# Altium schematic sheet Sheet1.SchDoc(Sheet1)
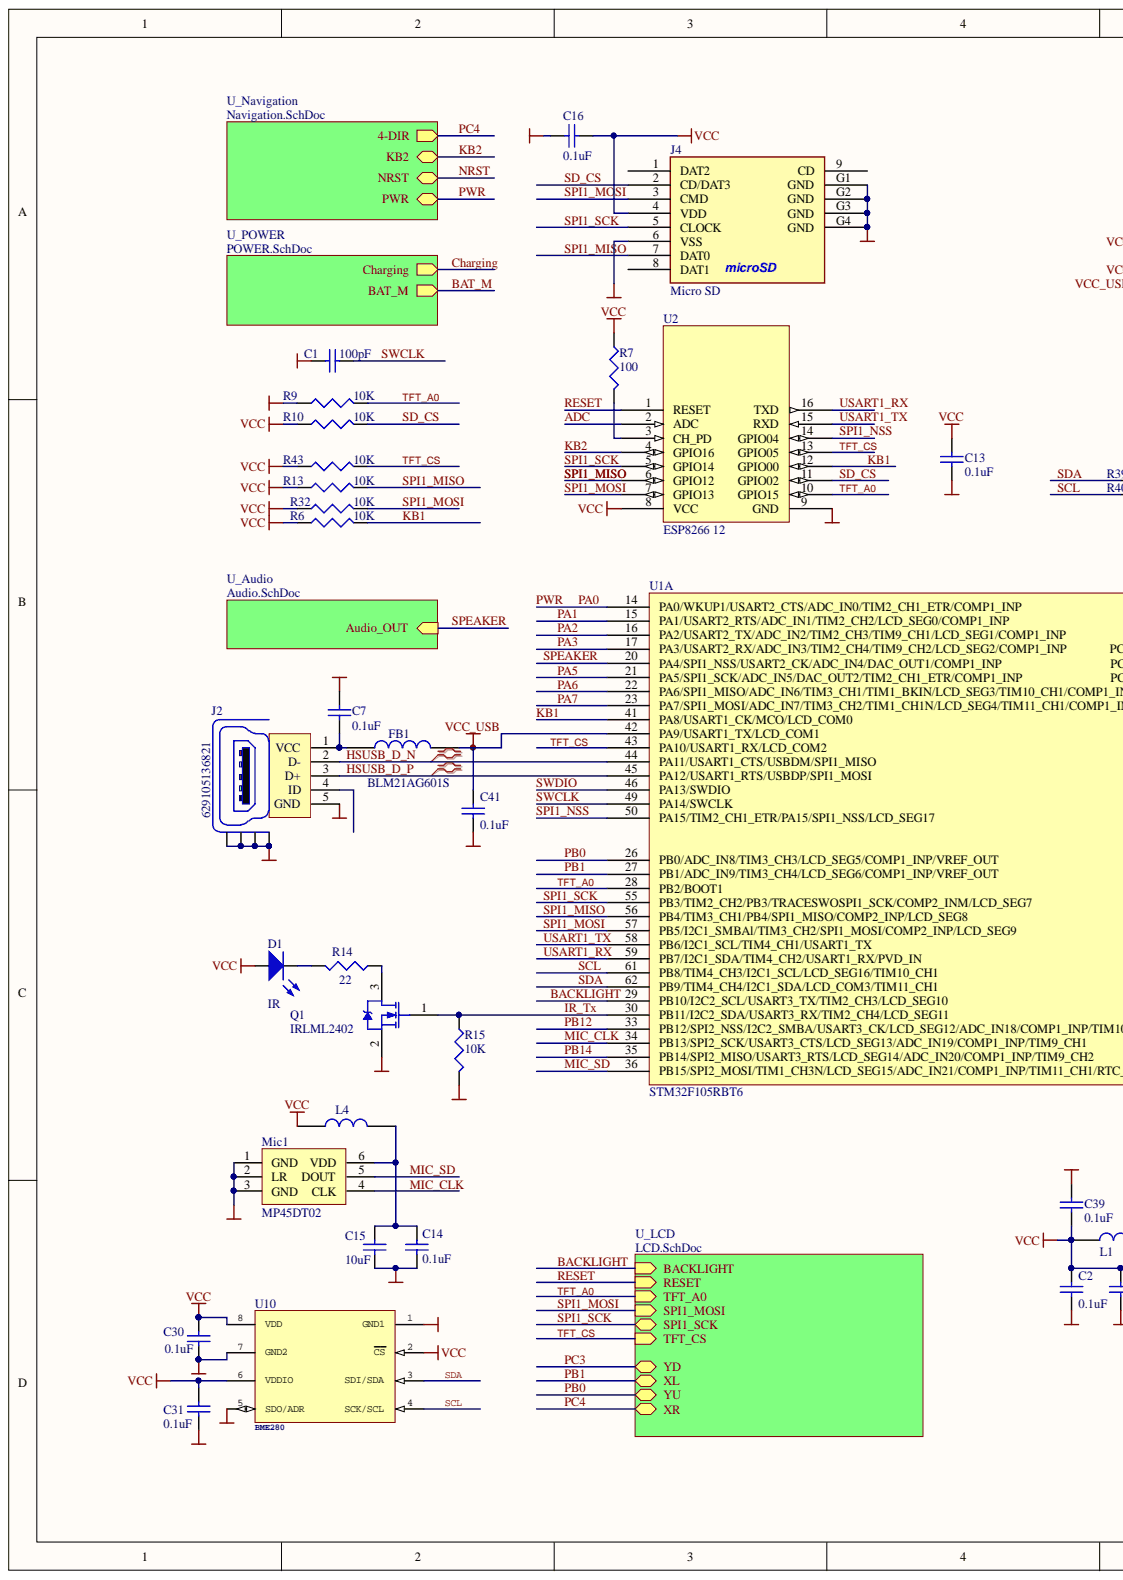
[diagram p0: Sheet1.SchDoc, left]
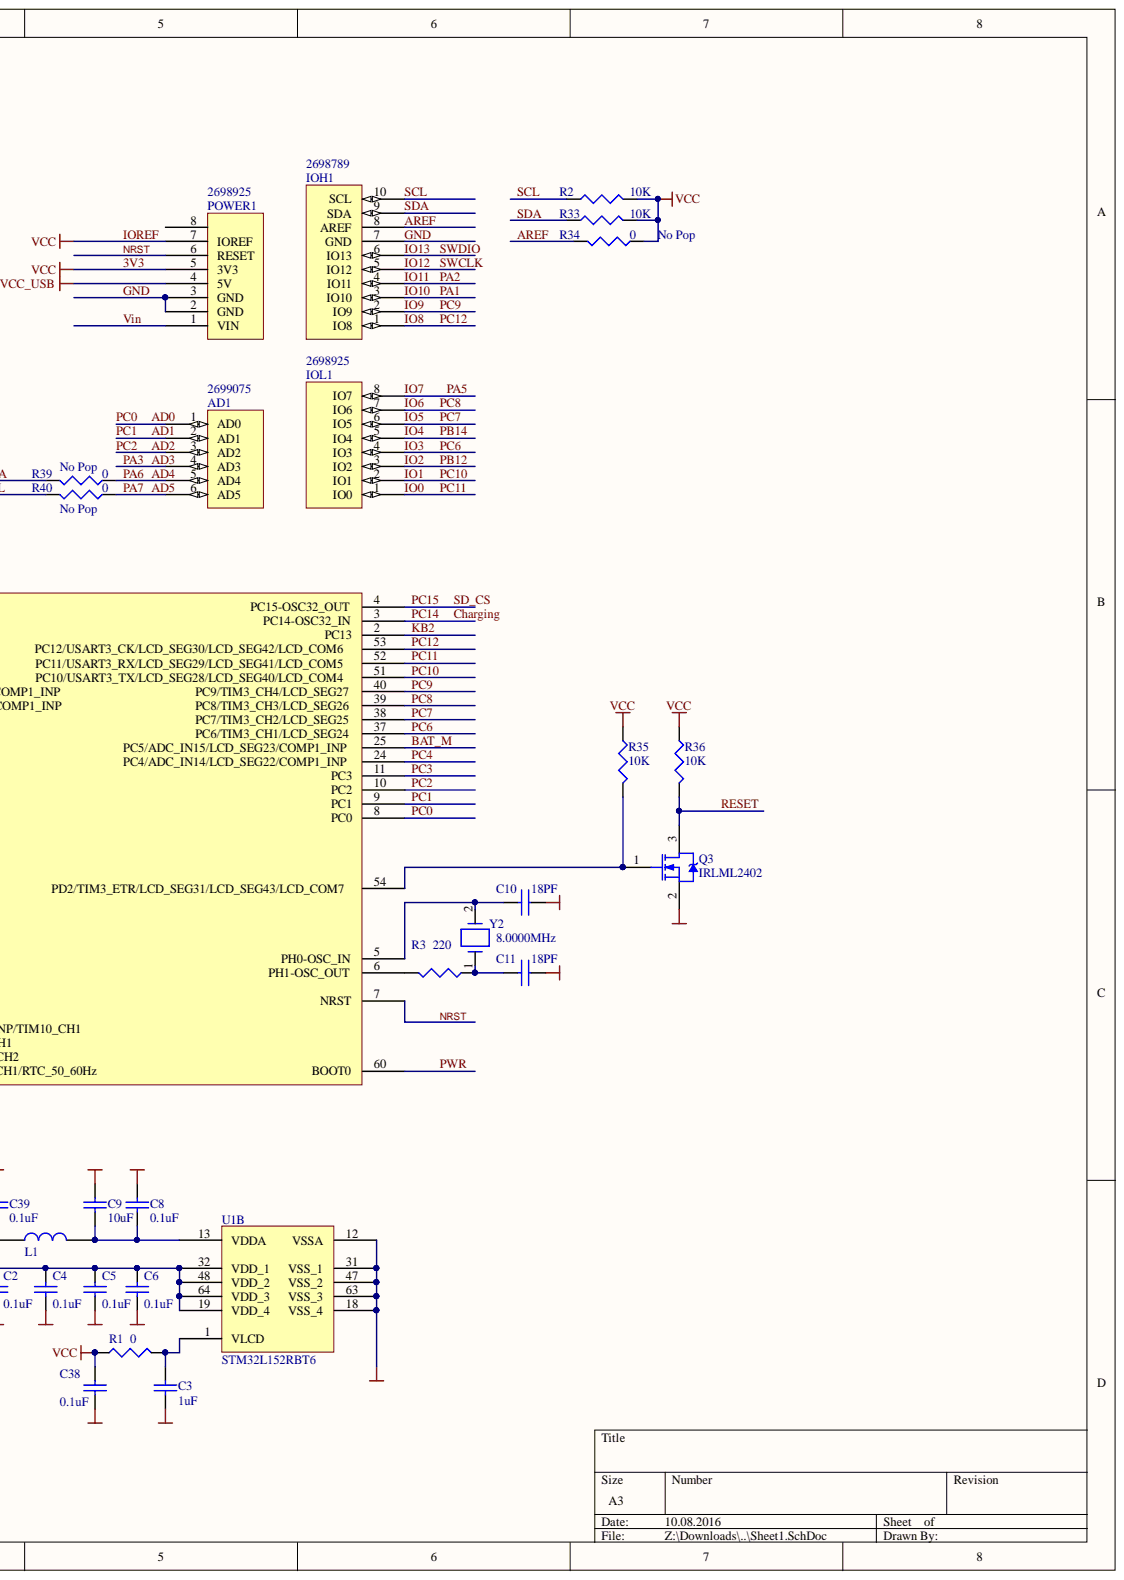
[diagram p1: Sheet1.SchDoc, right]

## Parts
AD1  AD  Supplier Part Number 4: 2699075  [Header 6x1 8.5mm]
C1  100pF  [CAPC1608N]
C2  0.1uF  [CAPC1005N]
C3  value 1uF  [CAPC1608N]  (hidden comment: 1uF 25V 20% 0603)
C4  0.1uF  [CAPC1005N]
C5  0.1uF  [CAPC1005N]
C6  0.1uF  [CAPC1005N]
C7  0.1uF  [CAPC1005N]
C8  0.1uF  [CAPC1005N]
C9  value 10uF  [CAPC1608N]  (hidden comment: CAP, MLCC, X5R, 10UF, 6.3V, 0603)
C10  18PF  [CAPC1608N]
C11  18PF  [CAPC1608N]
C13  0.1uF  [CAPC1005N]
C14  0.1uF  [CAPC1005N]
C15  value 10uF  [CAPC1608N]  (hidden comment: CAP, MLCC, X5R, 10UF, 6.3V, 0603)
C16  0.1uF  [CAPC1005N]
C30  0.1uF  [CAPC1005N]
C31  0.1uF  [CAPC1005N]
C38  0.1uF  [CAPC1005N]
C39  0.1uF  [CAPC1005N]
C41  0.1uF  [CAPC1005N]
D1  IR  [LED_5mm]
FB1  BLM21AG601S  [INDC2012N]
IOH1  IOH  Supplier Part Number 3: 2698789  [Header 10x1 8.5mm]
IOL1  IOL  Supplier Part Number 4: 2698925  [Header 8x1 8.5mm]
J2  629105136821  [629105136821]
J4  Micro SD  [TFP-09-2-12B]
L1  470OHM  [INDC1608N]  (value not drawn)
L4  470OHM  [INDC1608N]  (value not drawn)
Mic1  MP45DT02  [HLGA-6]
POWER1  Supplier Part Number 4: 2698925  [Header 8x1 8.5mm]  (hidden comment: POWER_UNO)
Q1  IRLML2402  [SOT-23]
Q3  IRLML2402  [SOT-23]
R1  0  [RESC1005N]
R2  10K  [RESC1005N]
R3  220  [RESC1005N]
R6  10K  [RESC1005N]
R7  value 100  [RESC1608N]  (hidden comment: RESISTOR;0603;1/10W;110 Ohm 5%)
R9  value 10K  [RESC1005N]  (hidden comment: RESISTOR 10K 1/10W 5% 0402)
R10  value 10K  [RESC1005N]  (hidden comment: RESISTOR 10K 1/10W 5% 0402)
R13  value 10K  [RESC1005N]  (hidden comment: RESISTOR 10K 1/10W 5% 0402)
R14  value 22  [RESC3216N]  (hidden comment: RES 22  OHM 5% 0.250W 1206)
R15  10K  [RESC1005N]
R32  10K  [RESC1005N]
R33  10K  [RESC1005N]
R34  0  ASSY_OPT: No Pop  [RESC1005N]
R35  10K  [RESC1005N]
R36  10K  [RESC1005N]
R39  0  ASSY_OPT: No Pop  [RESC1005N]
R40  0  ASSY_OPT: No Pop  [RESC1005N]
R43  value 10K  [RESC1005N]  (hidden comment: RESISTOR 10K 1/10W 5% 0402)
U1  STM32F105RBT6  [STM-LQFP64_N]  (parts A,B)
U2  ESP8266 12  [ESP-12-GREEN]
U10  BME280  [BME280_LGA]
Y2  value 8.0000MHz  [QUARTZ_5x3.2]  (hidden comment: Crystal 8.0000MHz 30ppm 18pF)

## Nets
VCC_USB: C41.1 FB1.2 POWER1.4(5V) U1.42(PA9/USART1_TX/LCD_COM1)
3V3: C2.1 C4.1 C5.1 C6.1 C13.1 C16.1 C30.1 C31.1 C38.1 C39.1 D1.1 J4.4(VDD) L1.2 L4.1 POWER1.5(3V3) POWER1.7(IOREF) R1.2 R2.1 R6.2 R7.1 R10.1 R13.1 R32.2 R33.1 R34.1 R35.2 R36.2 R43.1 U1.19(VDD_4) U1.32(VDD_1) U1.48(VDD_2) U1.64(VDD_3) U2.8(VCC) U10.2(CS) U10.6(VDDIO) U10.8(VDD)
AD0: AD1.1(AD0) U1.8(PC0)
AD1: AD1.2 U1.9(PC1)
AD2: AD1.3(AD2) U1.10(PC2)
AD3: AD1.4(AD3) U1.17(PA3/USART2_RX/ADC_IN3/TIM2_CH4/TIM9_CH2/LCD_SEG2/COMP1_INP)
AD4: AD1.5(AD4) R39.1 U1.22(PA6/SPI1_MISO/ADC_IN6/TIM3_CH1/TIM1_BKIN/LCD_SEG3/TIM10_CH1/COMP1_INP)
AD5: AD1.6(AD5) R40.1 U1.23(PA7/SPI1_MOSI/ADC_IN7/TIM3_CH2/TIM1_CH1N/LCD_SEG4/TIM11_CH1/COMP1_INP)
ADC: U2.2(ADC)
AREF: IOH1.8(AREF) R34.2
BACKLIGHT: U1.29(PB10/I2C2_SCL/USART3_TX/TIM2_CH3/LCD_SEG10)
BAT_M: U1.25(PC5/ADC_IN15/LCD_SEG23/COMP1_INP)
CHARGING: U1.3(PC14-OSC32_IN)
GND: C1.1 C2.2 C3.2 C4.2 C5.2 C6.2 C7.2 C8.2 C9.1 C10.1 C11.1 C13.2 C14.1 C15.1 C16.2 C30.2 C31.2 C38.2 C39.2 C41.2 IOH1.7(GND) J2.5(GND) J2.Z1 J2.Z2 J2.Z3 J2.Z4 J4.6(VSS) J4.G1(GND) J4.G2(GND) J4.G3(GND) J4.G4(GND) Mic1.1(GND) Mic1.2(LR) Mic1.3(GND) POWER1.2(GND) POWER1.3(GND) Q1.2 Q3.2 R9.1 R15.1 U1.12(VSSA) U1.18(VSS_4) U1.31(VSS_1) U1.47(VSS_2) U1.63(VSS_3) U2.9(GND) U10.1(GND1) U10.5(SDO/ADR) U10.7(GND2)
HSUSB_D_N: J2.2(D-) U1.44(PA11/USART1_CTS/USBDM/SPI1_MISO)
HSUSB_D_P: J2.3(D+) U1.45(PA12/USART1_RTS/USBDP/SPI1_MOSI)
IO0: IOL1.1(IO0) U1.52(PC11/USART3_RX/LCD_SEG29/LCD_SEG41/LCD_COM5)
IO1: IOL1.2(IO1) U1.51(PC10/USART3_TX/LCD_SEG28/LCD_SEG40/LCD_COM4)
IO2: IOL1.3(IO2) U1.33(PB12/SPI2_NSS/I2C2_SMBA/USART3_CK/LCD_SEG12/ADC_IN18/COMP1_INP/TIM10_CH1)
IO3: IOL1.4(IO3) U1.37(PC6/TIM3_CH1/LCD_SEG24)
IO4: IOL1.5(IO4) U1.35(PB14/SPI2_MISO/USART3_RTS/LCD_SEG14/ADC_IN20/COMP1_INP/TIM9_CH2)
IO5: IOL1.6(IO5) U1.38(PC7/TIM3_CH2/LCD_SEG25)
IO6: IOL1.7(IO6) U1.39(PC8/TIM3_CH3/LCD_SEG26)
IO7: IOL1.8(IO7) U1.21(PA5/SPI1_SCK/ADC_IN5/DAC_OUT2/TIM2_CH1_ETR/COMP1_INP)
IO8: IOH1.1(IO8) U1.53(PC12/USART3_CK/LCD_SEG30/LCD_SEG42/LCD_COM6)
IO9: IOH1.2(IO9) U1.40(PC9/TIM3_CH4/LCD_SEG27)
IO10: IOH1.3(IO10) U1.15(PA1/USART2_RTS/ADC_IN1/TIM2_CH2/LCD_SEG0/COMP1_INP)
IO11: IOH1.4(IO11) U1.16(PA2/USART2_TX/ADC_IN2/TIM2_CH3/TIM9_CH1/LCD_SEG1/COMP1_INP)
IO12: C1.2 IOH1.5(IO12) U1.49(PA14/SWCLK)
IO13: IOH1.6(IO13) U1.46(PA13/SWDIO)
IR_TX: Q1.1 R15.2 U1.30(PB11/I2C2_SDA/USART3_RX/TIM2_CH4/LCD_SEG11)
KB1: R6.1 U1.41(PA8/USART1_CK/MCO/LCD_COM0) U2.12(GPIO00)
KB2: U1.2(PC13) U2.4(GPIO16)
MIC_CLK: Mic1.4(CLK) U1.34(PB13/SPI2_SCK/USART3_CTS/LCD_SEG13/ADC_IN19/COMP1_INP/TIM9_CH1)
MIC_SD: Mic1.5(DOUT) U1.36(PB15/SPI2_MOSI/TIM1_CH3N/LCD_SEG15/ADC_IN21/COMP1_INP/TIM11_CH1/RTC_50_60Hz)
NRST: POWER1.6(RESET) U1.7(NRST)
PA0: U1.14(PA0/WKUP1/USART2_CTS/ADC_IN0/TIM2_CH1_ETR/COMP1_INP) U1.60(BOOT0)
PB0: U1.26(PB0/ADC_IN8/TIM3_CH3/LCD_SEG5/COMP1_INP/VREF_OUT)
PB1: U1.27(PB1/ADC_IN9/TIM3_CH4/LCD_SEG6/COMP1_INP/VREF_OUT)
PC3: U1.11(PC3)
PC4: U1.24(PC4/ADC_IN14/LCD_SEG22/COMP1_INP)
PC15: J4.2(CD/DAT3) R10.2 U1.4(PC15-OSC32_OUT) U2.11(GPIO02)
RESET: Q3.3 R36.1 U2.1(RESET)
SCL: IOH1.10(SCL) R2.2 R40.2 U1.61(PB8/TIM4_CH3/I2C1_SCL/LCD_SEG16/TIM10_CH1) U10.4(SCK/SCL)
SDA: IOH1.9(SDA) R33.2 R39.2 U1.62(PB9/TIM4_CH4/I2C1_SDA/LCD_COM3/TIM11_CH1) U10.3(SDI/SDA)
SPEAKER: U1.20(PA4/SPI1_NSS/USART2_CK/ADC_IN4/DAC_OUT1/COMP1_INP)
SPI1_MISO: J4.7(DAT0) R13.2 U1.56(PB4/TIM3_CH1/PB4/SPI1_MISO/COMP2_INP/LCD_SEG8) U2.6(GPIO12)
SPI1_MOSI: J4.3(CMD) R32.1 U1.57(PB5/I2C1_SMBAl/TIM3_CH2/SPI1_MOSI/COMP2_INP/LCD_SEG9) U2.7(GPIO13)
SPI1_NSS: U1.50(PA15/TIM2_CH1_ETR/PA15/SPI1_NSS/LCD_SEG17) U2.14(GPIO04)
SPI1_SCK: J4.5(CLOCK) U1.55(PB3/TIM2_CH2/PB3/TRACESWOSPI1_SCK/COMP2_INM/LCD_SEG7) U2.5(GPIO14)
TFT_A0: R9.2 U1.28(PB2/BOOT1) U2.10(GPIO15)
TFT_CS: R43.2 U1.43(PA10/USART1_RX/LCD_COM2) U2.13(GPIO05)
USART1_RX: U1.59(PB7/I2C1_SDA/TIM4_CH2/USART1_RX/PVD_IN) U2.16(TXD)
USART1_TX: U1.58(PB6/I2C1_SCL/TIM4_CH1/USART1_TX) U2.15(RXD)
VIN: POWER1.1(VIN)
NetC3_1: C3.1 R1.1 U1.1(VLCD)
NetC7_1: C7.1 FB1.1 J2.1(VCC)
NetC8_1: C8.1 C9.2 L1.1 U1.13(VDDA)
NetC10_2: C10.2 U1.5(PH0-OSC_IN) Y2.2
NetC11_2: C11.2 R3.2 Y2.1
NetC14_2: C14.2 C15.2 L4.2 Mic1.6(VDD)
NetD1_2: D1.2 R14.2
NetJ2_4: J2.4(ID)
NetJ4_1: J4.1(DAT2)
NetJ4_8: J4.8(DAT1)
NetJ4_9: J4.9(CD)
NetPOWER1_8: POWER1.8
NetQ1_3: Q1.3 R14.1
NetQ3_1: Q3.1 R35.1 U1.54(PD2/TIM3_ETR/LCD_SEG31/LCD_SEG43/LCD_COM7)
NetR3_1: R3.1 U1.6(PH1-OSC_OUT)
NetR7_2: R7.2 U2.3(CH_PD)

## Library data of the parts
C1 = Cap: CAP, MLCC, X7R, 100PF, 100V, 0603; Manufacturer: AVX; Part Number: 06031C101KAT2A; Component Kind: Standard; Distributor: Farnell: 2332570; Library Name: Miscellaneous Devices.IntLib; PackageReference: 0603; Price: 0.03; Replacement: CAP, MLCC, X7R, 100PF, 100V, 0603; Signal Integrity: Cap; Simulation: CAP; Value: 100pF
C2 C4-C8 C13 C14 C16 C30 C31 C38 C39 C41 = Cap: CAP CER 0.1UF 10V 10% X5R 0402; Manufacturer: AVX; Part Number: X5R-0.1UF-10V-10%-0402; Replacement: 0.1uF 10V 10% 0402; Component Kind: Standard; Library Name: Miscellaneous Devices.IntLib; PackageReference: 0402; Signal Integrity: Cap; Simulation: CAP; Value: 0.1uF
C3 = Cap: Manufacturer: AVX; Part Number: 06033D105MAT2A; Replacement: 1uF 6V 20% 0603; Component Kind: Standard; Description: 1uF 25V 20% 0603; Library Name: Miscellaneous Devices.IntLib; PackageReference: 0603; Signal Integrity: Cap; Simulation: CAP
C9 C15 = Cap: Manufacturer: MURATA; Part Number: GRM188R60J106ME47D; Component Kind: Standard; Description: CAP, MLCC, X5R, 10UF, 6.3V, 0603; Distributor: Farnell: 1735528RL; Library Name: Miscellaneous Devices.IntLib; PackageReference: 0603; Price: 0.1; Replacement: CAP, MLCC, X5R, 10UF, 6.3V, 0603; Signal Integrity: Cap; Simulation: CAP
C10 C11 = CAP NP: 18pF 0603 NPO; Manufacturer: PHYCOMP (YAGEO); Part Number: CC0603JRNPO9BN180; Component Kind: Standard; Distributor: Farnell: 721992; PackageReference: 0603; Price: 0.04; Replacement: 18pF 0603 NPO; Value: 18pF
D1 = LED_5mm: Typical INFRARED GaAs LED; Manufacturer: Vishay Semiconductor Opto Division; Part Number: TSAL6200; Color: IR; Component Kind: Standard; Distributor: Digi-Key Part Number 751-1204-ND; Library Name: AX_Altium_v2.3.IntLib; PackageReference: LED 5mm; Price: 0.5
FB1 = INDUCTOR: FERRITE BEAD, 0.21OHM, 600MA, 0805, 600ohm; Manufacturer: MURATA; Part Number: BLM21AG601SN1D; Component Kind: Standard; Distributor: Farnell: 1515622; PackageReference: 0805; Price: 0.073
J2 = WE-Communication Connectors 629105136821: WR-COM Micro USB SMT Type B; Manufacturer: Würth Elektronik eiSos GmbH; ComponentLink1 Description: Manufacturer; ComponentLink2 Description: Datasheet; NoPins: 5; 629105136821: 629105136821; Component Kind: Standard; ComponentLink1URL: http://www.we-online.de; ComponentLink2URL: http://katalog.we-online.de/em/datasheet/629105136821.pdf; Created Date: 10/10/2014; Library Name: USB-Mini_USB-Micro_USB.SchLib; Created by: Karrer Zheng
J4 = microSD_TFP-09-2-12B: Component Kind: Standard; Library Name: Schlib1.SCHLIB; PackageReference: Micro SD; Part Number: TFP-09-2-12B
L1 L4 = BEAD_FERRITE: IND FER BEAD 470OHM@100MHZ 1A 25% 0603; Manufacturer: MURATA; Part Number: BLM18PG471SH1D; FPLIST: IND_0603,IND0603_CC; Value: 470; Component Kind: Standard; Distributor: Farnell: 2443243; HEIGHT: 0.95MM; Library Name: Schlib1.SCHLIB; PackageReference: 0603; PART_TYPE: FERRITE; PRICE: 0.03; ROHS: Yes; Tolerance: 25%
Mic1 = MP45DT02: MEMS microphone; Component Kind: Standard; Library Name: MEMS.SchLib
Q1 Q3 = IRLML2402: HEXFET Power MOSFET; Manufacturer: International Rectifier; Part Number: IRLML2402TRPBF; Component Kind: Standard; Distributor: Digi-Key Part Number IRLML2402PBFCT-ND; Library Name: Schlib1.SCHLIB; PackageReference: SOT-23; Price: 0.3
R1 = R_Dup6: 0402 0ohm; Part Number: 0R-0.063W-0402; Component Kind: Standard; PackageReference: 0402; Price: 0.028; Replacement: 0402 0ohm; Value: 0
R2 R6 R9 R10 R13 R15 R32 R33 R35 R36 R43 = Res3: 10K 1/16W 5%  0402; Part Number: 10K-0.063W-5%-0402; Component Kind: Standard; Library Name: Miscellaneous Devices.IntLib; PackageReference: 0402; Replacement: 10K 1/16W 5%  0402; Signal Integrity: Res; Simulation: RESISTOR
  R2 R6 R15 R32 R33 R35 R36: Distributor: Farnell: 1469669RL; Price: 0.02; Value: 10K
  R9 R10 R13 R43: Price: 0.016
R3 = Res3: RESISTOR, 0402, 1%, 220R; Manufacturer: VISHAY DRALORIC; Part Number: CRCW0402220RFKEAHP; Component Kind: Standard; Distributor: Farnell: 1738841; Library Name: Miscellaneous Devices.IntLib; PackageReference: 0402; Price: 0.016; Replacement: RESISTOR, 0402, 1%, 220R; Signal Integrity: Res; Simulation: RESISTOR; Value: 220
R7 = Res3: Manufacturer: VISHAY DALE; Part Number: CRCW0603100RJNEA; Replacement: RESISTOR;0603;1/10W;100 Ohm 5%; Component Kind: Standard; Description: RESISTOR;0603;1/10W;110 Ohm 5%; Distributor: Digi-Key Part Number 541-100GTR-ND; Library Name: Miscellaneous Devices.IntLib; PackageReference: 0603; Signal Integrity: Res; Simulation: RESISTOR
R14 = RES: Manufacturer: VISHAY DALE; Part Number: CRCW120622R0JNEAIF; Component Kind: Standard; Description: RES 22  OHM 5% 0.250W 1206; Distributor: Farnell: 1739275; PackageReference: 1206; Replacement: RES 22  OHM 5% 0.250W 1206; Simulation: RESISTOR
R34 R39 R40 = Res3: 0402 0ohm; Part Number: 0R-0.063W-0402; Component Kind: Standard; Distributor: Farnell: 1469739RL; LatestRevisionDate: 04-Mar-2005; LatestRevisionNote: PCB Footprint replaced.; Library Name: Miscellaneous Devices.IntLib; PackageReference: 0402; Price: 0.028; Published: 8-Jun-2000; Replacement: 0402 0ohm; Signal Integrity: Res; Simulation: RESISTOR; Value: 0; Publisher: Altium Limited
U1 = STM32L152RCT6: 32-bit 256K MCU (SCORPIO2 Shrink); Manufacturer: STM; Component Kind: Standard; Library Name: MB963.SCHLIB; Manufacturer_Part_1: STM32F105RBT6; PackageReference: LQFP64; Part Number: STM32F105RBT6; Price: 5
U2 = ESP-12: Smart Connectivity Platform (ESCP); Manufacturer: Espressif Systems; Component Kind: Standard; Library Name: Schlib1.SCHLIB; PackageReference: ESP-12; Part Number: ESP-12
U10 = BME280: Combined humidity and pressure sensor; Manufacturer: Bosch Sensortec; Component Kind: Standard; Library Name: BME280.SCHLIB; Manufacturer Part Number: BME280; PackageReference: LGA; Part Number: BME280
Y2 = QUARTZ-32KHz-CM200S_0: Manufacturer: TXC CORPORATION; Part Number: 7A-8.000MAAJ-T; Component Kind: Standard; Description: Crystal 8.0000MHz 30ppm 18pF; Distributor: Digi-Key Part Number 887-1448-1-ND; Library Name: BB-xM_SCHEM_REVA3_Library.SchLib; PackageReference: 5 X 3.2; Replacement: http://www.quartz1.com/price/model.php?akt=620.15
AD1 = AD: Component Kind: Standard; Library Name: Header.SchLib; Supplier 1: Arrow; Supplier 2: Digi-Key; Supplier 3: Arrow; Supplier 4: Arrow; Supplier Part Number 1: 2699089; Supplier Part Number 2: SAM1198-06-ND; Supplier Part Number 3: 2699088
IOH1 = IOH: Component Kind: Standard; Library Name: Header.SchLib; Supplier 1: Arrow; Supplier 2: Digi-Key; Supplier 3: Arrow; Supplier Part Number 1: 2698803S4475693N1004; Supplier Part Number 2: SAM1198-10-ND
IOL1 = IOL: Component Kind: Standard; Library Name: Header.SchLib; Supplier 1: Digi-Key; Supplier 2: Arrow; Supplier 3: Arrow; Supplier 4: Arrow; Supplier Part Number 1: SAM1198-08-ND; Supplier Part Number 2: 2698939; Supplier Part Number 3: 2698940
POWER1 = POWER_UNO: Component Kind: Standard; Library Name: Header.SchLib; Supplier 1: Digi-Key; Supplier 2: Arrow; Supplier 3: Arrow; Supplier 4: Arrow; Supplier Part Number 1: SAM1198-08-ND; Supplier Part Number 2: 2698939; Supplier Part Number 3: 2698940
